# Altium schematic sheet DC_DC_15V_AND_VAR.SchDoc
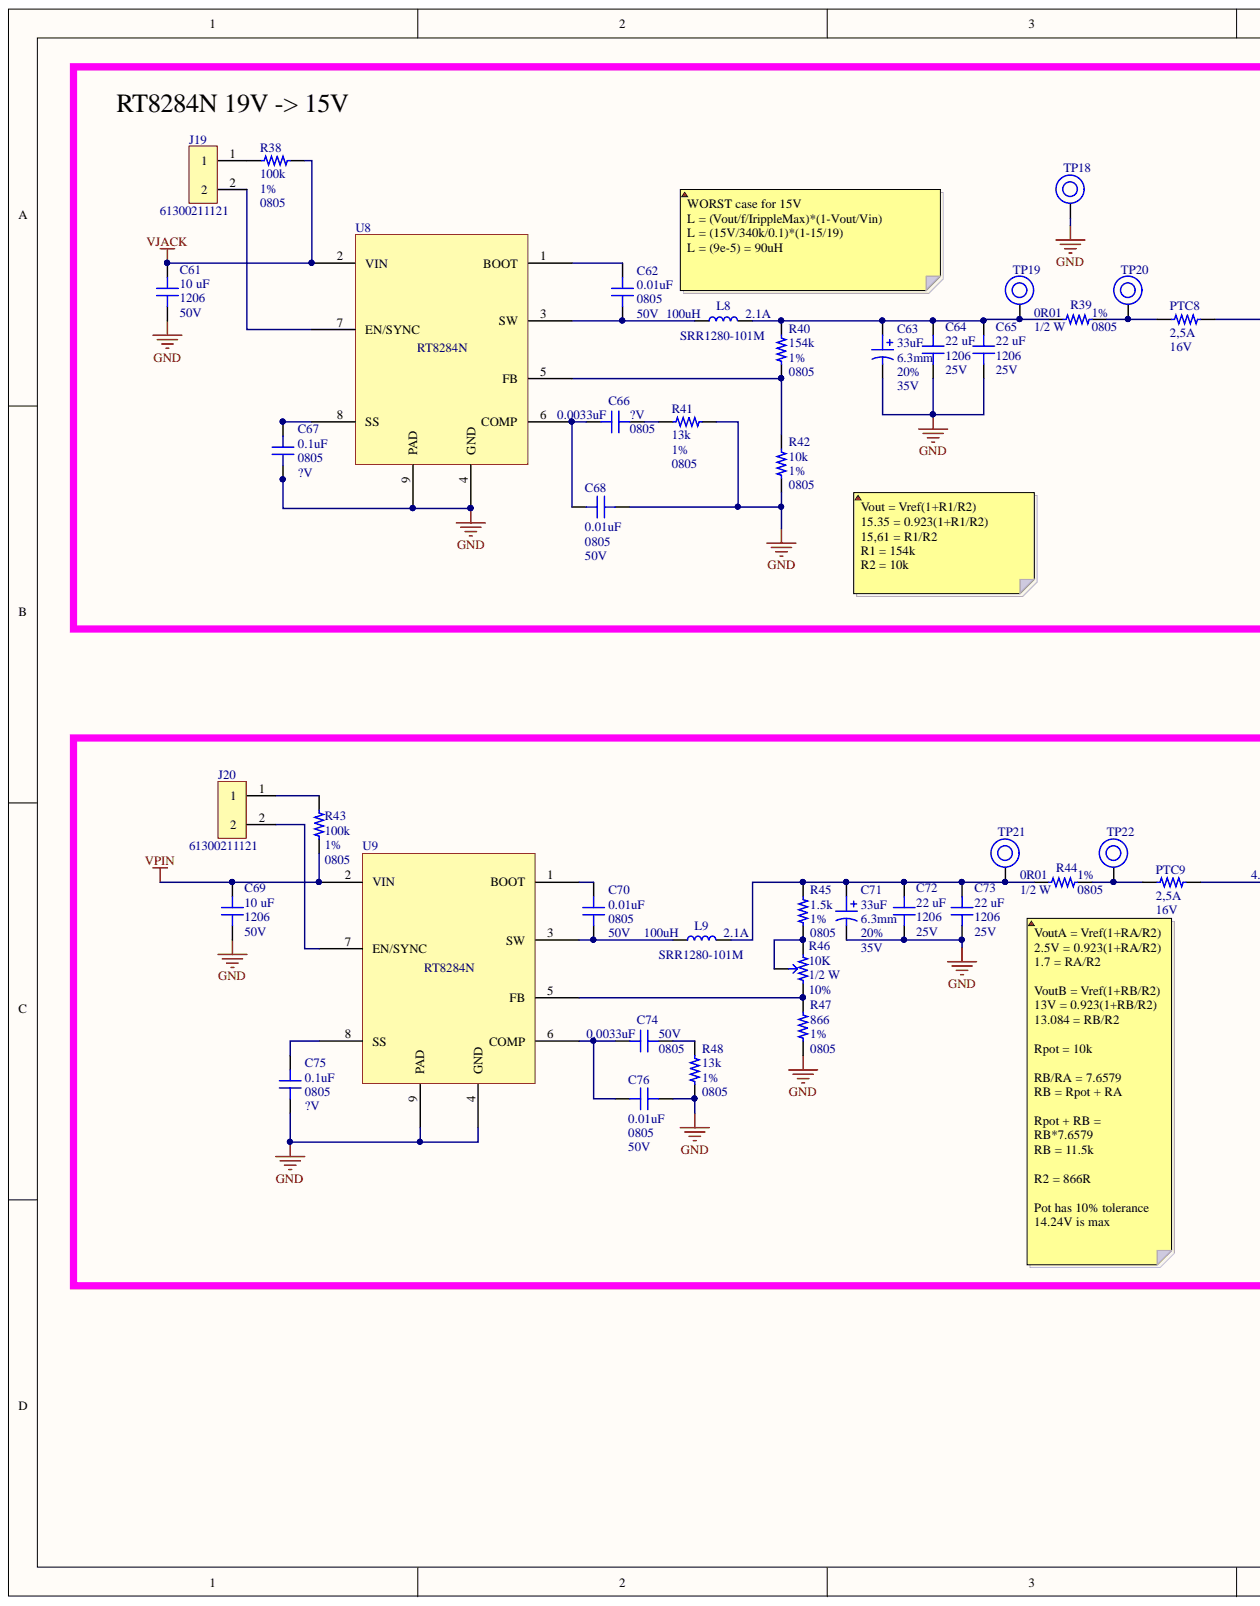
[diagram p0: DC_DC_15V_AND_VAR.SchDoc, left]
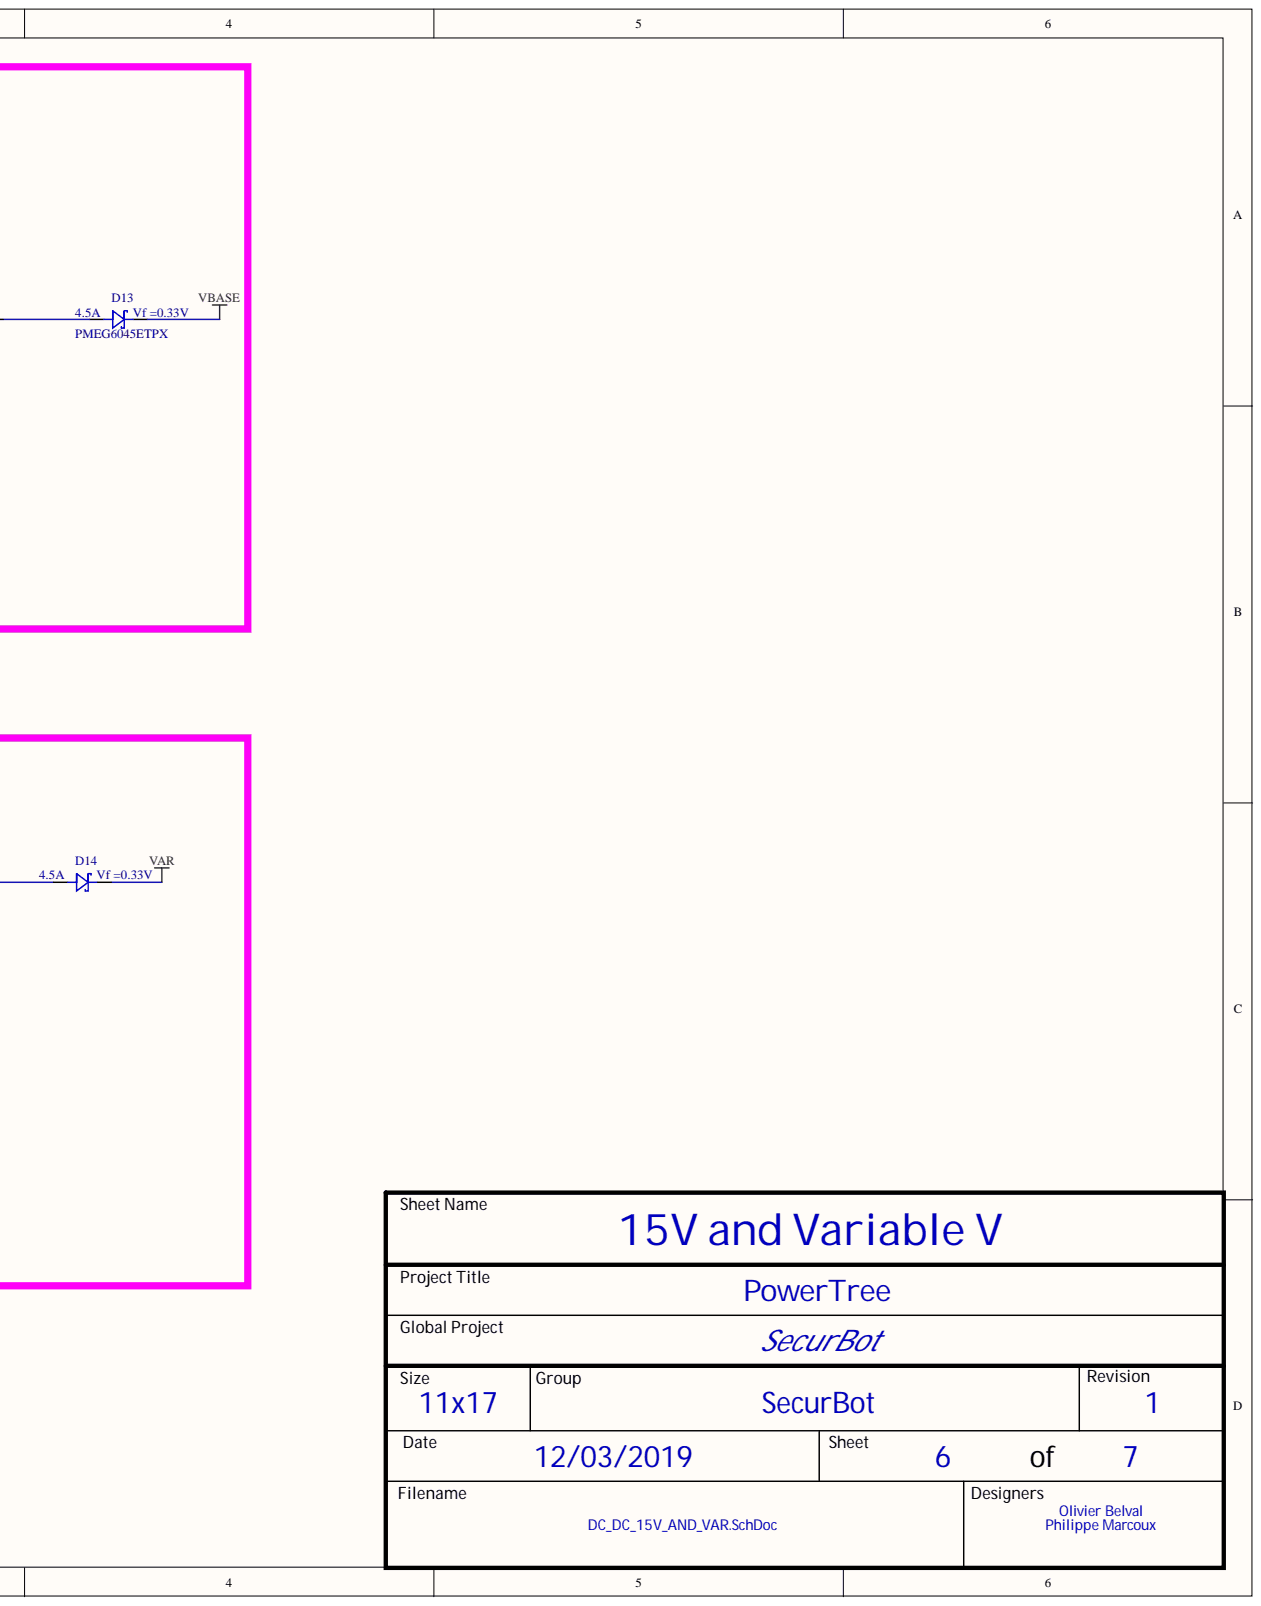
[diagram p1: DC_DC_15V_AND_VAR.SchDoc, right]

## Parts
C61  value 10 uF  Package: 1206  Voltage: 50V  [CAP_1206]  (hidden comment: CAP - 1206)
C62  value 0.01uF  Package: 0805  Voltage: 50V  [CAP_0805]  (hidden comment: CAP - 0805)
C63  value 33uF  Package: 6.3mm  Tolerance: 20%  Voltage: 35V  [CAP ALU - 6.3mm DIA]  (hidden comment: CAP ALU - 6.3mm)
C64  value 22 uF  Package: 1206  Voltage: 25V  [CAP_1206]  (hidden comment: CAP - 1206)
C65  value 22 uF  Package: 1206  Voltage: 25V  [CAP_1206]  (hidden comment: CAP - 1206)
C66  value 0.0033uF  Package: 0805  Voltage: ?V  [CAP_0805]  (hidden comment: CAP - 0805)
C67  value 0.1uF  Package: 0805  Voltage: ?V  [CAP_0805]  (hidden comment: CAP - 0805)
C68  value 0.01uF  Package: 0805  Voltage: 50V  [CAP_0805]  (hidden comment: CAP - 0805)
C69  value 10 uF  Package: 1206  Voltage: 50V  [CAP_1206]  (hidden comment: CAP - 1206)
C70  value 0.01uF  Package: 0805  Voltage: 50V  [CAP_0805]  (hidden comment: CAP - 0805)
C71  value 33uF  Package: 6.3mm  Tolerance: 20%  Voltage: 35V  [CAP ALU - 6.3mm DIA]  (hidden comment: CAP ALU - 6.3mm)
C72  value 22 uF  Package: 1206  Voltage: 25V  [CAP_1206]  (hidden comment: CAP - 1206)
C73  value 22 uF  Package: 1206  Voltage: 25V  [CAP_1206]  (hidden comment: CAP - 1206)
C74  value 0.0033uF  Package: 0805  Voltage: 50V  [CAP_0805]  (hidden comment: CAP - 0805)
C75  value 0.1uF  Package: 0805  Voltage: ?V  [CAP_0805]  (hidden comment: CAP - 0805)
C76  value 0.01uF  Package: 0805  Voltage: 50V  [CAP_0805]  (hidden comment: CAP - 0805)
D13  Current: 4.5A  Part number: PMEG6045ETPX  Vf: Vf =0.33V  [DO-214AC_SOD128]  (hidden comment: SCHOTTKEY)
D14  Current: 4.5A  Vf: Vf =0.33V  [DO-214AC_SOD128]  (hidden comment: SCHOTTKEY)
J19  Part number: 61300211121  [HDR1X2]  (hidden comment: Header 2 pin SM)
J20  Part number: 61300211121  [HDR1X2]  (hidden comment: Header 2 pin SM)
L8  value 100uH  Current: 2.1A  Part number: SRR1280-101M  [BOURNS 12.5x12.5mm]  (hidden comment: IND)
L9  value 100uH  Current: 2.1A  Part number: SRR1280-101M  [BOURNS 12.5x12.5mm]  (hidden comment: IND)
PTC8  Trip value: 2,5A  Voltage: 16V  [PTC - 1812]  (hidden comment: PTC - 1812)
PTC9  Trip value: 2,5A  Voltage: 16V  [PTC - 1812]  (hidden comment: PTC - 1812)
R38  value 100k  [FER_0805]  (hidden comment: RES - 0805)
R39  value 0R01  Package: 0805  Tolerance: 1%  Watt: 1/2 W  [FER_0805]  (hidden comment: RES - 0805)
R40  value 154k  Package: 0805  Tolerance: 1%  [FER_0805]  (hidden comment: RES - 0805)
R41  value 13k  Package: 0805  Tolerance: 1%  [FER_0805]  (hidden comment: RES - 0805)
R42  value 10k  Package: 0805  Tolerance: 1%  [FER_0805]  (hidden comment: RES - 0805)
R43  value 100k  Package: 0805  Tolerance: 1%  [FER_0805]  (hidden comment: RES - 0805)
R44  value 0R01  Package: 0805  Tolerance: 1%  Watt: 1/2 W  [FER_0805]  (hidden comment: RES - 0805)
R45  value 1.5k  Package: 0805  Tolerance: 1%  [FER_0805]  (hidden comment: RES - 0805)
R46  value 10K  Tolerance: 10%  Watt: 1/2 W  [3361P]  (hidden comment: Pot)
R47  value 866  Package: 0805  Tolerance: 1%  [FER_0805]  (hidden comment: RES - 0805)
R48  value 13k  Package: 0805  Tolerance: 1%  [FER_0805]  (hidden comment: RES - 0805)
TP18  TestPoint 3x3mm  [TestPoint 3X3mm]  (value not drawn)
TP19  TestPoint 3x3mm  [TestPoint 3X3mm]  (value not drawn)
TP20  TestPoint 3x3mm  [TestPoint 3X3mm]  (value not drawn)
TP21  TestPoint 3x3mm  [TestPoint 3X3mm]  (value not drawn)
TP22  TestPoint 3x3mm  [TestPoint 3X3mm]  (value not drawn)
U8  RT8284N  [SOP8]
U9  RT8284N  [SOP8]

## Nets
GND: C61.2 C63.2 C64.2 C65.2 C67.2 C68.2 C69.2 C71.2 C72.2 C73.2 C75.2 C76.2 R41.2 R42.2 R47.2 R48.1 TP18.1 U8.4(GND) U8.9(PAD) U9.4(GND) U9.9(PAD)
VAR: D14.2
VBASE: D13.2
VJACK: C61.1 R38.2 U8.2(VIN)
VPIN: C69.1 R43.1 U9.2(VIN)
NetC62_1: C62.1 U8.1(BOOT)
NetC62_2: C62.2 L8.1 U8.3(SW)
NetC63_1: C63.1 C64.1 C65.1 L8.2 R39.1 R40.1 TP19.1
NetC66_1: C66.1 C68.1 U8.6(COMP)
NetC66_2: C66.2 R41.1
NetC67_1: C67.1 U8.8(SS)
NetC70_1: C70.1 U9.1(BOOT)
NetC70_2: C70.2 L9.1 U9.3(SW)
NetC71_1: C71.1 C72.1 C73.1 L9.2 R44.1 R45.1 TP21.1
NetC74_1: C74.1 C76.1 U9.6(COMP)
NetC74_2: C74.2 R48.2
NetC75_1: C75.1 U9.8(SS)
NetD13_1: D13.1 PTC8.2
NetD14_1: D14.1 PTC9.2
NetJ19_1: J19.1 R38.1
NetJ19_2: J19.2 U8.7(EN/SYNC)
NetJ20_1: J20.1 R43.2
NetJ20_2: J20.2 U9.7(EN/SYNC)
NetPTC8_1: PTC8.1 R39.2 TP20.1
NetPTC9_1: PTC9.1 R44.2 TP22.1
NetR40_2: R40.2 R42.1 U8.5(FB)
NetR45_2: R45.2 R46.1 R46.3
NetR46_2: R46.2 R47.1 U9.5(FB)

## Library data of the parts
C61 C69 = CAP - 1206: Part number: GRT31CR61H106ME01L; Component Kind: Standard; Description: CAP - 1206; Library Name: SecureBot.SchLib
C62 C68 C70 C76 = CAP - 0805: Part number: CL21B103KBANNNC; Component Kind: Standard; Description: CAP - 0805; Library Name: SecureBot.SchLib
C63 C71 = CAP ALU - 6_3mm: Part number: EEE-FK1V330P; Component Kind: Standard; Description: CAP ALU - 6.3mm; Library Name: SecureBot.SchLib
C64 C65 C72 C73 = CAP - 1206: Part number: CL31A226KAHNNNE; Component Kind: Standard; Description: CAP - 1206; Library Name: SecureBot.SchLib
C66 C74 = CAP - 0805: Part number: CL21B332KBANNNC; Component Kind: Standard; Description: CAP - 0805; Library Name: SecureBot.SchLib
C67 C75 = CAP - 0805: Part number: CC0805KRX7R9BB104; Component Kind: Standard; Description: CAP - 0805; Library Name: SecureBot.SchLib
D14 = SCHOTTKEY: Part number: PMEG6045ETPX; Component Kind: Standard; Library Name: SecureBot.SchLib
PTC8 PTC9 = PTC - 1812: Part number: 0ZCG0160BF2C; Component Kind: Standard; Description: PTC - 1812; Package: 1812; Res: ?; Library Name: SecureBot.SchLib
R38 = RES - 0805: Part number: RMCF0805FT100K; Tolerance: 1%; Watt: ? W; Component Kind: Standard; Description: RES - 0805; Package: 0805; Library Name: SecureBot.SchLib
R39 R44 = RES - 0805: Part number: KRL1220E-M-R010-F-T5; Component Kind: Standard; Description: RES - 0805; Library Name: SecureBot.SchLib
R40 = RES - 0805: Part number: RC0805FR-07154KL; Watt: ? W; Component Kind: Standard; Description: RES - 0805; Library Name: SecureBot.SchLib
R41 R48 = RES - 0805: Part number: RC0805FR-0713KL; Watt: ? W; Component Kind: Standard; Description: RES - 0805; Library Name: SecureBot.SchLib
R42 = RES - 0805: Part number: RMCF0805FT10K0; Watt: ? W; Component Kind: Standard; Description: RES - 0805; Library Name: SecureBot.SchLib
R43 = RES - 0805: Part number: RMCF0805FT100K; Watt: ? W; Component Kind: Standard; Description: RES - 0805; Library Name: SecureBot.SchLib
R45 = RES - 0805: Part number: CRCW08051K50FKEA; Watt: ? W; Component Kind: Standard; Description: RES - 0805; Library Name: SecureBot.SchLib
R46 = Pot: Part number: 3361P-1-103GLF; Component Kind: Standard; Description: Pot; Package: ?; Library Name: SecureBot.SchLib
R47 = RES - 0805: Part number: RC0805FR-07866RL; Watt: ? W; Component Kind: Standard; Description: RES - 0805; Library Name: SecureBot.SchLib
D13 = SCHOTTKEY: Component Kind: Standard; Library Name: SecureBot.SchLib
J19 J20 = Header 2 pin SM: Component Kind: Standard; Library Name: SecureBot.SchLib
L8 L9 = IND: Component Kind: Standard; Description: IND; Package: ?; Library Name: SecureBot.SchLib
TP18-TP22 = TestPoint 3x3mm: Component Kind: Standard; Library Name: SecureBot.SchLib
U8 U9 = RT8284N: Component Kind: Standard; Description: RT8284N; Part number: RT8284N; Library Name: SecureBot.SchLib
